# Altium schematic sheet Sheet1.SchDoc(Sheet1) (continued)

## Library data of the parts
C1 C7 C10 C12 C13 C16 C17 C19 C23 = GRM155R71C104KA88D: Multilayer Ceramic Capacitor, 0.1 uF, 16 V, ï¿½ 10%, X7R, 0402 [1005 Metric]; Manufacturer: Murata; Capacitance: 100nF; Dielectric Material: 4241ConductorMaterial; Voltage: 16V; Case Code (Imperial): 0402; Case Code (Metric): 1005; Case/Package: 0402; Category: Ceramic Capacitors; Component Kind: Standard; ComponentLink1Description: https://datasheet.ciiva.com/129/grm155r71c104ka88-01-129739.pdf?src-supplier=Digikey; ComponentLink1URL: https://datasheet.ciiva.com/129/grm155r71c104ka88-01-129739.pdf?src-supplier=Digikey; ComponentLink2Description: https://datasheet.ciiva.com/129/grm155r71c104ka88-01-129740.pdf?src-supplier=Digikey; ComponentLink2URL: https://datasheet.ciiva.com/129/grm155r71c104ka88-01-129740.pdf?src-supplier=Digikey; ComponentLink3Description: https://datasheet.ciiva.com/18102/getdatasheetpartid-85307-18102905.pdf?src-supplier=Verical; ComponentLink3URL: https://datasheet.ciiva.com/18102/getdatasheetpartid-85307-18102905.pdf?src-supplier=Verical; ComponentLink4Description: https://datasheet.ciiva.com/29199/454098-da-01-en-vs-kondensator-0402-29199799.pdf?src-supplier=Conrad; ComponentLink4URL: https://datasheet.ciiva.com/29199/454098-da-01-en-vs-kondensator-0402-29199799.pdf?src-supplier=Conrad; ComponentLink5Description: https://datasheet.ciiva.com/7937/4360-7937615.pdf?src-supplier=Element14; ComponentLink5URL: https://datasheet.ciiva.com/7937/4360-7937615.pdf?src-supplier=Element14; ComponentLink6Description: https://datasheet.ciiva.com/28534/4360-28534127.pdf?src-supplier=Element14; ComponentLink6URL: https://datasheet.ciiva.com/28534/4360-28534127.pdf?src-supplier=Element14; ComponentLink7Description: https://datasheet.ciiva.com/28534/4360-28534133.pdf?src-supplier=Element14; ComponentLink7URL: https://datasheet.ciiva.com/28534/4360-28534133.pdf?src-supplier=Element14; ComponentLink8Description: https://datasheet.ciiva.com/16199/product-16199000.pdf?src-supplier=Future+Electronics; ComponentLink8URL: https://datasheet.ciiva.com/16199/product-16199000.pdf?src-supplier=Future+Electronics; ComponentLink9Description: https://datasheet.ciiva.com/14103/grmseries-highdielectrictype-eng-14103750.pdf?src-supplier=Distrelec; ComponentLink9URL: https://datasheet.ciiva.com/14103/grmseries-highdielectrictype-eng-14103750.pdf?src-supplier=Distrelec; ComponentLink10Description: https://datasheet.ciiva.com/14116/grmseries-highdielectrictype-eng-14116878.pdf?src-supplier=Distrelec; ComponentLink10URL: https://datasheet.ciiva.com/14116/grmseries-highdielectrictype-eng-14116878.pdf?src-supplier=Distrelec; Depth: 0.5mm; Dielectric: X7R; Height: 0.5mm; Lead Free: Lead Free; Length: 1mm; Library Name: Schlib1.SchLib; Manufacturer Part Number: GRM155R71C104KA88D; Material: Ceramic; Max Operating Temperature: 125°C; Min Operating Temperature: -55°C; Mount: Surface Mount; Number of Pins: 2; Package Quantity: 1; Packaging: Tape and Reel; Pricing 1: 10000=0,0044, 40000=0,0043, 100000=0,0042, 250000=0,004, 400000=0,0039 (USD); Radiation Hardening: No; Reach SVHC Compliant: Unknown; RoHS: Yes; RoHS Compliant: Yes; Series: GRM; Stock 1: 33520000; Supplier 1: Future Electronics; Supplier Part Number 1: 5964861; Termination: SMD/SMT; Thickness: 0.022inch; Tolerance: 10%; Voltage Rating: 16V; Voltage Rating (DC): 16V; Width: 0.02inch
C2-C5 = TMK107F474ZA-T: Multilayer Ceramic Capacitors (High dielectric type), 25V, 0.47 uF, +80%, 0603, Y5V/F, RoHS; Manufacturer: Taiyo; Dielectric: Y5V; Value: 470nF; Case/Package: 0603; Component Kind: Standard; Halogen Free: Halogen Free; Height: 800um; Lead Free: Lead Free; Length: 1.6mm; Library Name: Schlib1.SchLib; Manufacturer Part Number: TMK107F474ZA-T; Packaging: Cut Tape; Pins: 2; RoHS: No; Supplier: Newark; Supplier Part Number: 08P5185; Voltage Rating (DC): 25V; Width: 800um
C6 C8 C9 C11 C14 = CC1206KKX7R7BB105: Multilayer Ceramic Capacitor, 1 uF, 16 V, ï¿½ 10%, X7R, 1206 [3216 Metric]; Manufacturer: Yageo; Capacitance: 1uF; Insulation Resistance: 100GR; Material: 4241ConductorMaterial; Voltage Rating: 16V; Case Code (Imperial): 1206; Case Code (Metric): 3216; Case/Package: 1206; Category: Ceramic Capacitors; Component Kind: Standard; ComponentLink1Description: https://datasheet.ciiva.com/178/mlccorderingcode-178166.pdf?src-supplier=Digikey; ComponentLink1URL: https://datasheet.ciiva.com/178/mlccorderingcode-178166.pdf?src-supplier=Digikey; ComponentLink2Description: https://datasheet.ciiva.com/178/mlccorderingcode-178167.pdf?src-supplier=Digikey; ComponentLink2URL: https://datasheet.ciiva.com/178/mlccorderingcode-178167.pdf?src-supplier=Digikey; ComponentLink3Description: https://datasheet.ciiva.com/178/mlccorderingcode-178168.pdf?src-supplier=Digikey; ComponentLink3URL: https://datasheet.ciiva.com/178/mlccorderingcode-178168.pdf?src-supplier=Digikey; ComponentLink4Description: https://datasheet.ciiva.com/7978/1489800-7978229.pdf?src-supplier=Element14; ComponentLink4URL: https://datasheet.ciiva.com/7978/1489800-7978229.pdf?src-supplier=Element14; ComponentLink5Description: https://datasheet.ciiva.com/11464/upy-gphc-x7r-6-3v-to-50v-8-11464763.pdf?src-supplier=Avnet; ComponentLink5URL: https://datasheet.ciiva.com/11464/upy-gphc-x7r-6-3v-to-50v-8-11464763.pdf?src-supplier=Avnet; Depth: 1.6mm; Dielectric: X7R; Dielectric Material: Ceramic; Halogen Free: Halogen Free; Height: 1.15mm; Lead Free: Lead Free; Length: 3.2mm; Library Name: Schlib1.SchLib; Manufacturer Part Number: CC1206KKX7R7BB105; Max Operating Temperature: 125°C; Min Operating Temperature: -55°C; Mount: Surface Mount; Number of Pins: 2; Package Quantity: 1; Packaging: Tape and Reel; Pricing 1: 3000=0,0252 (USD); Radiation Hardening: No; Reach SVHC Compliant: No; RoHS: Yes; RoHS Compliant: Yes; Series: CC; Stock 1: 174000; Supplier 1: Future Electronics; Supplier Part Number 1: 9057753; Termination: SMD/SMT; Thickness: 0.045inch; Tolerance: 10%; Voltage Rating (AC): 16V; Voltage Rating (DC): 16V; Width: 0.063inch
C15 C18 = GRM1555C1H8R0DZ01D: Cap Ceramic 8pF 50V C0G 0.5pF SMD 0402 125°C Paper T/R; Manufacturer: Murata; Case Code (Imperial): 0402; Case Code (Metric): 1005; Case/Package: 0402; Category: Ceramic Capacitors; Component Kind: Standard; ComponentLink1Description: https://datasheet.ciiva.com/3778/8361grm1555c1h8r0dz0123-3778464.pdf?src-supplier=Arrow+Electronics; ComponentLink1URL: https://datasheet.ciiva.com/3778/8361grm1555c1h8r0dz0123-3778464.pdf?src-supplier=Arrow+Electronics; ComponentLink2Description: https://datasheet.ciiva.com/5413/product-5413574.pdf?src-supplier=Mouser; ComponentLink2URL: https://datasheet.ciiva.com/5413/product-5413574.pdf?src-supplier=Mouser; ComponentLink3Description: https://datasheet.ciiva.com/11610/product-11610154.pdf?src-supplier=Avnet; ComponentLink3URL: https://datasheet.ciiva.com/11610/product-11610154.pdf?src-supplier=Avnet; Construction: Flat; Depth: 0.5mm; Dielectric: C0G; Height: 0.5mm; Lead Free: Lead Free; Length: 1mm; Library Name: Schlib1.SchLib; Manufacturer Part Number: GRM1555C1H8R0DZ01D; Max Operating Temperature: 125°C; Min Operating Temperature: -55°C; Mounting Technology: Surface Mount; Package Quantity: 10000; Packaging: Tape and Reel; Pricing: 10=0,05, 100=0,04, 1000=0,04, 10000=0,04 (USD); Radiation Hardening: No; RoHS: Yes; RoHS Compliant: Yes; Series: GRM; Stock: 59869; Supplier: CXDA Electronics; Supplier Part Number: GRM1555C1H8R0DZ01D; Termination: SMD/SMT; Thickness: 0.022inch; Value: 8pF; Voltage Rating: 50V; Voltage Rating (DC): 50V; Width: 0.02inch
C20 C22 = TPSA105K020R3000: Cap Tant Solid 1uF 20V A CASE 10%( 3.2 X 1.6 X 1.6mm) Inward L SMD 3216-18 3 Ohm 125C T/R; Manufacturer: Kyocera AVX; Dielectric Material: Tantalum; Dissipation Factor: 4%; ESR (Equivalent Series Resistance): 3R; Leakage Current: 500nA; Polarity: Polar; Case Code (Imperial): 1206; Case Code (Metric): 3216; Case/Package: 1206; Category: Tantalum Capacitors; Component Kind: Standard; ComponentLink1Description: https://datasheet.ciiva.com/26341/806571-da-01-en-smd-tantal1uf-20v-10-3-000r-2000st-26341825.pdf?src-supplier=Conrad; ComponentLink1URL: https://datasheet.ciiva.com/26341/806571-da-01-en-smd-tantal1uf-20v-10-3-000r-2000st-26341825.pdf?src-supplier=Conrad; ComponentLink2Description: https://datasheet.ciiva.com/263/tps-263911.pdf?src-supplier=Digikey; ComponentLink2URL: https://datasheet.ciiva.com/263/tps-263911.pdf?src-supplier=Digikey; ComponentLink3Description: https://datasheet.ciiva.com/263/tps-263912.pdf?src-supplier=Digikey; ComponentLink3URL: https://datasheet.ciiva.com/263/tps-263912.pdf?src-supplier=Digikey; ComponentLink4Description: https://datasheet.ciiva.com/263/tps-263913.pdf?src-supplier=Digikey; ComponentLink4URL: https://datasheet.ciiva.com/263/tps-263913.pdf?src-supplier=Digikey; ComponentLink5Description: https://datasheet.ciiva.com/7832/1441576-7832617.pdf?src-supplier=Element14; ComponentLink5URL: https://datasheet.ciiva.com/7832/1441576-7832617.pdf?src-supplier=Element14; ComponentLink6Description: https://datasheet.ciiva.com/7832/1441576-7832845.pdf?src-supplier=Element14; ComponentLink6URL: https://datasheet.ciiva.com/7832/1441576-7832845.pdf?src-supplier=Element14; ComponentLink7Description: https://datasheet.ciiva.com/7832/1441576-7832846.pdf?src-supplier=Element14; ComponentLink7URL: https://datasheet.ciiva.com/7832/1441576-7832846.pdf?src-supplier=Element14; ComponentLink8Description: https://datasheet.ciiva.com/7832/tps-7832847.pdf?src-supplier=Element14; ComponentLink8URL: https://datasheet.ciiva.com/7832/tps-7832847.pdf?src-supplier=Element14; ComponentLink9Description: https://datasheet.ciiva.com/28555/1932865-28555241.pdf?src-supplier=Element14; ComponentLink9URL: https://datasheet.ciiva.com/28555/1932865-28555241.pdf?src-supplier=Element14; ComponentLink10Description: https://datasheet.ciiva.com/12910/tps-23028-12910247.pdf?src-supplier=Mouser; ComponentLink10URL: https://datasheet.ciiva.com/12910/tps-23028-12910247.pdf?src-supplier=Mouser; ComponentLink11Description: https://datasheet.ciiva.com/16224/tps-16224686.pdf?src-supplier=Future+Electronics; ComponentLink11URL: https://datasheet.ciiva.com/16224/tps-16224686.pdf?src-supplier=Future+Electronics; ComponentLink12Description: https://datasheet.ciiva.com/14590/70001047-14590583.pdf?src-supplier=Allied+Electronics; ComponentLink12URL: https://datasheet.ciiva.com/14590/70001047-14590583.pdf?src-supplier=Allied+Electronics; ComponentLink13Description: https://datasheet.ciiva.com/14590/70001047-14590587.pdf?src-supplier=Allied+Electronics; ComponentLink13URL: https://datasheet.ciiva.com/14590/70001047-14590587.pdf?src-supplier=Allied+Electronics; ComponentLink14Description: https://datasheet.ciiva.com/3004/tps-3004579.pdf?src-supplier=Avnet; ComponentLink14URL: https://datasheet.ciiva.com/3004/tps-3004579.pdf?src-supplier=Avnet; Construction: Flat; Depth: 1.6mm; Features: General Purpose; Height: 1.6mm; Height - Seated (Max): 0.071inch; Lead Free: Lead Free; Lead Pitch: 1.1mm; Length: 3.2mm; Library Name: Schlib1.SchLib; Manufacturer Part Number: TPSA105K020R3000; Max Operating Temperature: 125°C; Min Operating Temperature: -55°C; Mounting Technology: Surface Mount,PCB; Package Quantity: 1; Packaging: Tape and Reel; Pins: 2; Pricing: 1=0,76, 10=0,459, 50=0,3398, 100=0,3028, 500=0,2398, 1000=0,22014, 2000=0,20387, 4000=0,19039, 6000=0,18361, 10000=0,17607, 14000=0,17164, 20000=0,16737, 50000=0,15812 (USD); Radiation Hardening: No; Reach SVHC Compliant: No; RoHS: Yes; RoHS Compliant: Yes; Series: TPS; Stock: 46298; Supplier: Digikey; Supplier Part Number: 478-2399-1-ND; Termination: SMD/SMT; Tolerance: 10%; Value: 1uF; Voltage: 20V; Voltage Rating: 20V; Voltage Rating (DC): 20V; Weight: 0.000571oz; Width: 1.6mm
C21 = B45197A3107K509: Cap 100UF 16VDC 10% 7.3 X 4.3 X 4.1MM SMD 7343-43 T/r; Manufacturer: KEMET; Dielectric Material: Tantalum; Dissipation Factor: 8%; ESR (Equivalent Series Resistance): 100mR; Leakage Current: 16uA; Voltage: 16V; Capacitance: 100uF; Case Code (Imperial): 2917; Case Code (Metric): 7343; Case/Package: 2917; Category: Tantalum Capacitors; Component Kind: Standard; ComponentLink1Description: https://datasheet.ciiva.com/5934/58264-5934481.pdf?src-supplier=Farnell; ComponentLink1URL: https://datasheet.ciiva.com/5934/58264-5934481.pdf?src-supplier=Farnell; ComponentLink2Description: https://datasheet.ciiva.com/11793/0900766b809b4274-11793801.pdf?src-supplier=Rs; ComponentLink2URL: https://datasheet.ciiva.com/11793/0900766b809b4274-11793801.pdf?src-supplier=Rs; ComponentLink3Description: https://datasheet.ciiva.com/1464/1761160-1464734.pdf?src-supplier=Element14; ComponentLink3URL: https://datasheet.ciiva.com/1464/1761160-1464734.pdf?src-supplier=Element14; ComponentLink4Description: https://datasheet.ciiva.com/1464/1761160-1464735.pdf?src-supplier=Element14; ComponentLink4URL: https://datasheet.ciiva.com/1464/1761160-1464735.pdf?src-supplier=Element14; Depth: 4.3mm; Height: 4.4mm; Lead Free: Lead Free; Length: 7.3mm; Library Name: Schlib1.SchLib; Manufacturer Part Number: B45197A3107K509; Max Operating Temperature: 125°C; Min Operating Temperature: -55°C; Mount: Surface Mount; Number of Pins: 2; Packaging: Tape and Reel; Pricing 1: 1=2,31, 10=1,54, 50=1,07, 100=0,889, 200=0,771, 400=0,66, 2000=0,578 (GBP); Reach SVHC Compliant: No; RoHS: Yes; RoHS Compliant: Yes; Series: B45197A; Supplier 1: Farnell; Supplier Part Number 1: 9751084; Termination: SMD/SMT; Tolerance: 10%; Voltage Rating: 16V; Voltage Rating (DC): 16V; Weight: 0.023634oz; Width: 4.3mm
C24 = TAJA105K016RNJ: Cap Tant Solid 1uF 16V A CASE 10%( 3.2 X 1.6 X 1.6mm) Inward L SMD 3216-18 11 Ohm 125C T/R; Manufacturer: Kyocera AVX; Dielectric Material: Tantalum; Dissipation Factor: 4%; ESR (Equivalent Series Resistance): 11R; Leakage Current: 500nA; Life (Hours): 2000Hour; Ripple Current: 83mA; Tolerance: 20%; Capacitance: 1uF; Case Code (Imperial): 1206; Case Code (Metric): 3216; Case/Package: 1206; Category: Tantalum Capacitors; Component Kind: Standard; ComponentLink1Description: https://datasheet.ciiva.com/263/taj-263409.pdf?src-supplier=Digikey; ComponentLink1URL: https://datasheet.ciiva.com/263/taj-263409.pdf?src-supplier=Digikey; ComponentLink2Description: https://datasheet.ciiva.com/263/taj-263410.pdf?src-supplier=Digikey; ComponentLink2URL: https://datasheet.ciiva.com/263/taj-263410.pdf?src-supplier=Digikey; ComponentLink3Description: https://datasheet.ciiva.com/263/taj-263411.pdf?src-supplier=Digikey; ComponentLink3URL: https://datasheet.ciiva.com/263/taj-263411.pdf?src-supplier=Digikey; ComponentLink4Description: https://datasheet.ciiva.com/18710/taj-eng-18710647.pdf?src-supplier=Distrelec; ComponentLink4URL: https://datasheet.ciiva.com/18710/taj-eng-18710647.pdf?src-supplier=Distrelec; ComponentLink5Description: https://datasheet.ciiva.com/7832/1703059-7832429.pdf?src-supplier=Element14; ComponentLink5URL: https://datasheet.ciiva.com/7832/1703059-7832429.pdf?src-supplier=Element14; ComponentLink6Description: https://datasheet.ciiva.com/7832/1703059-7832430.pdf?src-supplier=Element14; ComponentLink6URL: https://datasheet.ciiva.com/7832/1703059-7832430.pdf?src-supplier=Element14; ComponentLink7Description: https://datasheet.ciiva.com/7832/1680476-7832431.pdf?src-supplier=Element14; ComponentLink7URL: https://datasheet.ciiva.com/7832/1680476-7832431.pdf?src-supplier=Element14; ComponentLink8Description: https://datasheet.ciiva.com/7832/taj-7832810.pdf?src-supplier=Element14; ComponentLink8URL: https://datasheet.ciiva.com/7832/taj-7832810.pdf?src-supplier=Element14; ComponentLink9Description: https://datasheet.ciiva.com/7832/taj-7832811.pdf?src-supplier=Element14; ComponentLink9URL: https://datasheet.ciiva.com/7832/taj-7832811.pdf?src-supplier=Element14; Depth: 1.6mm; Features: General Purpose; Height: 1.6mm; Lead Free: Lead Free; Lead Pitch: 1.1mm; Length: 3.2mm; Library Name: Schlib1.SchLib; Manufacturer Part Number: TAJA105K016RNJ; Max Operating Temperature: 125°C; Min Operating Temperature: -55°C; Mount: Surface Mount,PCB; Number of Pins: 2; Packaging: Tape and Reel; Pricing 1: 1=0,38, 10=0,225, 50=0,1626, 100=0,1431, 500=0,11, 1000=0,09966 (USD); Reach SVHC Compliant: No; RoHS: Yes; RoHS Compliant: Yes; Series: TAJ; Stock 1: 80915; Supplier 1: Digikey; Supplier Part Number 1: 478-1649-1-ND; Termination: SMD/SMT; Voltage: 16V; Voltage Rating: 16V; Voltage Rating (AC): 16V; Voltage Rating (DC): 16V; Weight: 0.000571oz; Width: 1.6mm
D1 D2 = LNJ314G8TRA: Led SMT Grn Cut Tapes; Manufacturer: Panasonic SSG; Color: Green; Forward Current: 10mA; Forward Voltage: 2.03V; Lens Size: 0.8mm; Lens Style: Flat,Rectangular; Luminous Intensity: 5mcd; Number of LEDs: 1; Power Rating: 40mW; Wavelength: 565nm; Case Code (Imperial): 0603; Case Code (Metric): 1608; Case/Package: 0603; Category: LEDs; Component Kind: Standard; ComponentLink1Description: https://datasheet.ciiva.com/9326/lnj314g8tra-bek-discon-9326750.pdf?src-supplier=Avnet; ComponentLink1URL: https://datasheet.ciiva.com/9326/lnj314g8tra-bek-discon-9326750.pdf?src-supplier=Avnet; Height: 0.5mm; Illumination Color: Green; Lead Free: Lead Free; Length: 1.6mm; Library Name: Schlib1.SchLib; Manufacturer Part Number: LNJ314G8TRA; Max Operating Temperature: 85°C; Min Operating Temperature: -30°C; Mount: Surface Mount; Number of Pins: 2; Packaging: Tape and Reel; Pricing 1: 1=1,03385 (USD); Radiation Hardening: No; RoHS: Yes; RoHS Compliant: Yes; Series: -; Stock 1: 450; Supplier 1: Ampacity Systems; Supplier Part Number 1: LNJ314G8TRA; Test Current: 10mA; Width: 0.8mm
D3 = SD0805S020S1R0: Small Signal Schottky Diode, Single, 20 V, 1 A, 450 Mv, 10 A, 125 C Rohs Compliant: Yes; Manufacturer: Kyocera AVX; Average Rectified Current: 1A; Forward Voltage: 450mV; Max Forward Surge Current (Ifsm): 10A; Max Repetitive Reverse Voltage (Vrrm): 20V; Max Reverse Current: 200uA; Case Code (Imperial): 0805; Case Code (Metric): 2012; Case/Package: 0805; Category: Rectifier Diodes; Component Kind: Standard; ComponentLink1Description: https://datasheet.ciiva.com/29883/schottky-29883740.pdf?src-supplier=Avnet; ComponentLink1URL: https://datasheet.ciiva.com/29883/schottky-29883740.pdf?src-supplier=Avnet; ComponentLink2Description: https://datasheet.ciiva.com/29883/schottky-29883741.pdf?src-supplier=Avnet; ComponentLink2URL: https://datasheet.ciiva.com/29883/schottky-29883741.pdf?src-supplier=Avnet; ComponentLink3Description: https://datasheet.ciiva.com/13084/schottky-221155-13084174.pdf?src-supplier=Mouser; ComponentLink3URL: https://datasheet.ciiva.com/13084/schottky-221155-13084174.pdf?src-supplier=Mouser; Forward Current: 1A; Library Name: Schlib1.SchLib; Manufacturer Part Number: SD0805S020S1R0; Max Operating Temperature: 125°C; Max Reverse Leakage Current: 200uA; Max Reverse Voltage (DC): 20V; Max Surge Current: 10A; Min Operating Temperature: -55°C; Mounting Technology: Surface Mount; Packaging: Cut Tape; Peak Non-Repetitive Surge Current: 10A; Pins: 2; Pricing: 1=0,51, 10=0,311, 100=0,1972, 500=0,14792, 1000=0,132, 3000=0,11174, 6000=0,10152, 9000=0,09631, 15000=0,09046, 21000=0,08699, 30000=0,08362, 75000=0,07622 (USD); Radiation Hardening: No; Reach SVHC Compliant: No; RoHS: Yes; RoHS Compliant: Yes; Series: -; Stock: 72775; Supplier: Digikey; Supplier Part Number: 478-7800-1-ND
H1 H2 = 1725656: Block, Terminal; 6 A; 63 V; 2.54 mm; 26 to 20 AWG; 2; Screw; Soldering; 1.5 kV; Manufacturer: Phoenix Contact; Approvals: UL,CSA,cULus,EAC; Connector Type: Screw,PCB,Terminal Block; Current: 6A; Max Supply Voltage: 63V; Number of Circuits: 2; Number of Levels: 1; Pitch: 2.54mm; Stripping Length: 4.5mm; Voltage: 125V; Wire Gauge (Max): 26AWG; Wire Gauge (Min): 20AWG; Category: Terminal Blocks; Color: Green; Component Kind: Standard; ComponentLink1Description: https://datasheet.ciiva.com/823/1725656-823793.pdf?src-supplier=Digikey; ComponentLink1URL: https://datasheet.ciiva.com/823/1725656-823793.pdf?src-supplier=Digikey; ComponentLink2Description: https://datasheet.ciiva.com/1120/319957-1120510.pdf?src-supplier=Farnell; ComponentLink2URL: https://datasheet.ciiva.com/1120/319957-1120510.pdf?src-supplier=Farnell; ComponentLink3Description: https://datasheet.ciiva.com/15169/getdatasheetpartid-199323-15169849.pdf?src-supplier=Verical; ComponentLink3URL: https://datasheet.ciiva.com/15169/getdatasheetpartid-199323-15169849.pdf?src-supplier=Verical; ComponentLink4Description: https://datasheet.ciiva.com/16071/1725656-16071846.pdf?src-supplier=Onlinecomponents.com; ComponentLink4URL: https://datasheet.ciiva.com/16071/1725656-16071846.pdf?src-supplier=Onlinecomponents.com; ComponentLink5Description: https://datasheet.ciiva.com/26340/788052-da-01-en-100ntklemme-1725656-250-st-26340907.pdf?src-supplier=Conrad; ComponentLink5URL: https://datasheet.ciiva.com/26340/788052-da-01-en-100ntklemme-1725656-250-st-26340907.pdf?src-supplier=Conrad; Contact Material: Tin; Current Rating: 6A; Depth: 6.2mm; Flammability Rating: UL94 V-0; Height: 6.2mm; Housing Color: 49Colour; Housing Material: Polyamide; Insulation Material: 4261ConductorMaterial; Lead Free: Lead Free; Length: 5.54mm; Library Name: Schlib1.SchLib; Manufacturer Part Number: 1725656; Max Current Rating: 6A; Mount: Through Hole; Number of Contacts: 2; Number of Pins: 2; Number of Poles: 2; Number of Positions: 2; Number of Positions Per Level: 2; Number of Rows: 1; Orientation: Straight; Package Quantity: 50; Packaging: Bulk; Pricing 1: 1=1,66, 10=1,14, 50=1,14, 100=1,14, 1000=1,13, 10000=1,13 (USD); Radiation Hardening: No; Reach SVHC Compliant: No; RoHS: Yes; RoHS Compliant: Yes; Series: MPT; Stock 1: 18801; Supplier 1: Mouser; Supplier Part Number 1: 651-1725656; Termination: Solder; Voltage Rating: 125V; Weight: 0.022575oz; Width: 12mm; Wire/Cable Gauge: 26AWG
H3 = 1725669: Terminal Block Connector; 6 A; 63 V; 2.54 mm; 26 to 20 AWG; 3; Screw; Soldering; 1.5 kV; Manufacturer: Phoenix Contact; Approvals: UL,CSA,cULus,EAC; Connector Type: Screw,PCB,Terminal Block; Current: 6A; Max Supply Voltage: 63V; Number of Circuits: 3; Number of Levels: 1; Pitch: 2.54mm; Stripping Length: 4.5mm; Voltage: 125V; Wire Gauge (Max): 26AWG; Wire Gauge (Min): 20AWG; Category: Terminal Blocks; Color: Green; Component Kind: Standard; ComponentLink1Description: https://datasheet.ciiva.com/823/1725669-823803.pdf?src-supplier=Digikey; ComponentLink1URL: https://datasheet.ciiva.com/823/1725669-823803.pdf?src-supplier=Digikey; ComponentLink2Description: https://datasheet.ciiva.com/1118/1761576-1118544.pdf?src-supplier=Element14; ComponentLink2URL: https://datasheet.ciiva.com/1118/1761576-1118544.pdf?src-supplier=Element14; ComponentLink3Description: https://datasheet.ciiva.com/7053/312657-7053092.pdf?src-supplier=Newark; ComponentLink3URL: https://datasheet.ciiva.com/7053/312657-7053092.pdf?src-supplier=Newark; ComponentLink4Description: https://datasheet.ciiva.com/3969/1725669-3969975.pdf?src-supplier=Arrow+Electronics; ComponentLink4URL: https://datasheet.ciiva.com/3969/1725669-3969975.pdf?src-supplier=Arrow+Electronics; ComponentLink5Description: https://datasheet.ciiva.com/15169/getdatasheetpartid-178016-15169707.pdf?src-supplier=Verical; ComponentLink5URL: https://datasheet.ciiva.com/15169/getdatasheetpartid-178016-15169707.pdf?src-supplier=Verical; ComponentLink6Description: https://datasheet.ciiva.com/16071/1725669-16071848.pdf?src-supplier=Onlinecomponents.com; ComponentLink6URL: https://datasheet.ciiva.com/16071/1725669-16071848.pdf?src-supplier=Onlinecomponents.com; ComponentLink7Description: https://datasheet.ciiva.com/14607/70054336-14607349.pdf?src-supplier=Allied+Electronics; ComponentLink7URL: https://datasheet.ciiva.com/14607/70054336-14607349.pdf?src-supplier=Allied+Electronics; ComponentLink8Description: https://datasheet.ciiva.com/29223/717267-da-01-en-printklemme-1725669-250-st-29223852.pdf?src-supplier=Conrad; ComponentLink8URL: https://datasheet.ciiva.com/29223/717267-da-01-en-printklemme-1725669-250-st-29223852.pdf?src-supplier=Conrad; Contact Material: Tin; Current Rating: 6A; Depth: 6.2mm; Flammability Rating: UL94 V-0; Height: 8.08mm; Housing Color: Green; Housing Material: Polyamide; Insulation Material: Nylon; Lead Free: Lead Free; Length: 6.2mm; Library Name: Schlib1.SchLib; Manufacturer Part Number: 1725669; Max Current Rating: 6A; Mount: Through Hole; Number of Contacts: 3; Number of Pins: 3; Number of Poles: 3; Number of Positions: 3; Number of Positions Per Level: 3; Number of Rows: 1; Orientation: Straight; Package Quantity: 250; Packaging: Bulk; Pricing 1: 10=2,04, 100=1,77, 250=1,72, 500=1,68, 1000=1,64, 2500=1,61, 5000=1,59, 7500=1,57 (USD); Radiation Hardening: No; Reach SVHC Compliant: No; RoHS: Yes; RoHS Compliant: Yes; Series: MPT; Stock 1: 743; Supplier 1: Onlinecomponents.com; Supplier Part Number 1: 1725669; Termination: Solder; Voltage Rating: 125V; Weight: 0.021658oz; Width: 13mm; Wire/Cable Gauge: 26AWG
H4 = MPT0,5/4-2,54: Wire-To-Board Terminal Block Rating to EN: 6 A @ 63 VAC/VDC Rating to UL: 6 A @ 125 VAC/VDC Temperature range: -20...; Manufacturer: Phoenix Contact; Max Current Rating: 6A; Max Supply Voltage: 63V; Wire Gauge (Max): 26AWG; Wire Gauge (Min): 20AWG; Category: Terminal Blocks; Component Kind: Standard; ComponentLink1Description: https://www.distrelec.biz/Web/Downloads/20/76/uu142076.pdf?mime=application%2Fpdf&src-supplier=Distrelec; ComponentLink1URL: https://www.distrelec.biz/Web/Downloads/20/76/uu142076.pdf?mime=application%2Fpdf&src-supplier=Distrelec; Depth: 6.2mm; Housing Material: 4261ConductorMaterial; Length: 10.62mm; Library Name: Schlib1.SchLib; Manufacturer Part Number: MPT0,5/4-2,54; Number of Pins: 4; Number of Rows: 1NUMBER; Orientation: 5491OrientationType; RoHS: Yes; RoHS Compliant: Yes; Stock 1: 49; Supplier 1: Sourcengine; Supplier Part Number 1: MPT0,5/4-2,54; Termination: Screw
Q1 = BC817-25,235: BC817 Series 45V 500mA SMT NPN General Purpose Transistor - SOT-23; Manufacturer: Nexperia; Collector Base Voltage (VCBO): 50V; Collector Emitter Saturation Voltage: 700mV; Emitter Base Voltage (VEBO): 5V; hFE Min: 160; Number of Elements: 1; Power Dissipation: 250mW; Category: BJTs; Collector Emitter Breakdown Voltage: 45V; Collector Emitter Voltage (VCEO): 45V; Component Kind: Standard; ComponentLink1Description: https://datasheet.octopart.com/BC817-25%2C235-Nexperia-datasheet-108335331.pdf?src-supplier=Farnell; ComponentLink1URL: https://datasheet.octopart.com/BC817-25%2C235-Nexperia-datasheet-108335331.pdf?src-supplier=Farnell; ComponentLink2Description: https://assets.nexperia.com/documents/data-sheet/BC817_SER.pdf?src-supplier=Digikey; ComponentLink2URL: https://assets.nexperia.com/documents/data-sheet/BC817_SER.pdf?src-supplier=Digikey; Height: 1.1mm; Library Name: Schlib1.SchLib; Manufacturer Part Number: BC817-25,235; Max Collector Current: 500mA; Max Operating Temperature: 150°C; Number of Pins: 3; Packaging: Tape and Reel; Polarity: NPN; Pricing 1: 10000=0,0123, 30000=0,0119, 50000=0,0117, 100000=0,0114, 200000=0,011 (USD); RoHS: Yes; RoHS Compliant: Yes; Stock 1: 6440000; Supplier 1: Future Electronics; Supplier Part Number 1: 3451903
Q2 Q3 = MMBT6427-7-F: MMBT6427 Series 40 V 500 mA NPN Surface Mount Darlington Transistor - SOT-23-3; Manufacturer: Diodes; Collector Base Voltage (VCBO): 40V; Collector Emitter Saturation Voltage: 1.2V; Collector-emitter Voltage-Max: 1.5V; Current Rating: 500mA; Emitter Base Voltage (VEBO): 12V; hFE Min: 10000; Max Power Dissipation: 300mW; Number of Elements: 1; Case/Package: SOT-23; Category: BJTs; Collector Emitter Breakdown Voltage: 40V; Collector Emitter Voltage (VCEO): 40V; Component Kind: Standard; ComponentLink1Description: https://datasheet.ciiva.com/11211/ds30048-11211443.pdf?src-supplier=Avnet; ComponentLink1URL: https://datasheet.ciiva.com/11211/ds30048-11211443.pdf?src-supplier=Avnet; ComponentLink2Description: https://datasheet.ciiva.com/4459/ds30048-82966-4459317.pdf?src-supplier=Mouser; ComponentLink2URL: https://datasheet.ciiva.com/4459/ds30048-82966-4459317.pdf?src-supplier=Mouser; ComponentLink3Description: https://datasheet.ciiva.com/15139/getdatasheetpartid-67553-15139920.pdf?src-supplier=Verical; ComponentLink3URL: https://datasheet.ciiva.com/15139/getdatasheetpartid-67553-15139920.pdf?src-supplier=Verical; ComponentLink4Description: https://datasheet.ciiva.com/973/ds30048-973062.pdf?src-supplier=Digikey; ComponentLink4URL: https://datasheet.ciiva.com/973/ds30048-973062.pdf?src-supplier=Digikey; ComponentLink5Description: https://datasheet.ciiva.com/973/ds30048-973063.pdf?src-supplier=Digikey; ComponentLink5URL: https://datasheet.ciiva.com/973/ds30048-973063.pdf?src-supplier=Digikey; Height: 1mm; Lead Free: Lead Free; Length: 3.05mm; Library Name: Schlib1.SchLib; Manufacturer Part Number: MMBT6427-7-F; Max Breakdown Voltage: 40V; Max Collector Current: 500mA; Max Operating Temperature: 150°C; Min Operating Temperature: -55°C; Mount: Surface Mount; Number of Pins: 3; Package Quantity: 3000; Packaging: Tape and Reel; Polarity: NPN; Pricing 1: 1=0,21, 10=0,128, 100=0,0796, 500=0,05812, 1000=0,05115 (USD); Radiation Hardening: No; RoHS: Yes; RoHS Compliant: Yes; Series: Automotive, AEC-Q101; Stock 1: 25429; Supplier 1: Digikey; Supplier Part Number 1: MMBT6427-FDICT-ND; Voltage Rating (DC): 40V; Weight: 0.000282oz; Width: 1.4mm
R1 R3 R11 R15 R18 = CRCW04021K00JNED: Res Thick Film 0402 1K Ohm 5% 1/16W ±200ppm/°C Molded SMD SMD Paper T/R; Manufacturer: Vishay Dale; Max Power Dissipation: 63mW; Resistance: 1kR; Voltage Rating: 50V; Case Code (Imperial): 0402; Case Code (Metric): 1005; Case/Package: 0402; Category: Chip SMD Resistors; Component Kind: Standard; ComponentLink1Description: https://datasheet.ciiva.com/2462/dcrcwe3-2462076.pdf?src-supplier=Digikey; ComponentLink1URL: https://datasheet.ciiva.com/2462/dcrcwe3-2462076.pdf?src-supplier=Digikey; ComponentLink2Description: https://datasheet.ciiva.com/2462/dcrcwe3-2462077.pdf?src-supplier=Digikey; ComponentLink2URL: https://datasheet.ciiva.com/2462/dcrcwe3-2462077.pdf?src-supplier=Digikey; ComponentLink3Description: https://datasheet.ciiva.com/2462/dcrcwe3-2462078.pdf?src-supplier=Digikey; ComponentLink3URL: https://datasheet.ciiva.com/2462/dcrcwe3-2462078.pdf?src-supplier=Digikey; ComponentLink4Description: https://datasheet.ciiva.com/7743/dcrcwe3-7743478.pdf?src-supplier=Element14; ComponentLink4URL: https://datasheet.ciiva.com/7743/dcrcwe3-7743478.pdf?src-supplier=Element14; ComponentLink5Description: https://datasheet.ciiva.com/5545/dcrcwe3-109170-5545913.pdf?src-supplier=Mouser; ComponentLink5URL: https://datasheet.ciiva.com/5545/dcrcwe3-109170-5545913.pdf?src-supplier=Mouser; ComponentLink6Description: https://datasheet.ciiva.com/28621/1847332-28621342.pdf?src-supplier=Element14; ComponentLink6URL: https://datasheet.ciiva.com/28621/1847332-28621342.pdf?src-supplier=Element14; ComponentLink7Description: https://datasheet.ciiva.com/16199/dcrcwe3-16199256.pdf?src-supplier=Future+Electronics; ComponentLink7URL: https://datasheet.ciiva.com/16199/dcrcwe3-16199256.pdf?src-supplier=Future+Electronics; ComponentLink8Description: https://datasheet.ciiva.com/16778/70201119-16778053.pdf?src-supplier=Allied+Electronics; ComponentLink8URL: https://datasheet.ciiva.com/16778/70201119-16778053.pdf?src-supplier=Allied+Electronics; Construction: Rectangular; Contact Plating: Tin; Depth: 0.5mm; Features: Automotive AEC-Q200; Height: 0.016inch; Lead Free: Lead Free; Length: 1mm; Library Name: Schlib1.SchLib; Manufacturer Part Number: CRCW04021K00JNED; Max Operating Temperature: 155°C; Military Standard: Not; Min Operating Temperature: -55°C; Number of Pins: 2; Number of Terminations: 2; Package Quantity: 1; Packaging: Tape and Reel; Power Rating: 63mW; Pricing 1: 1=0,1, 10=0,016, 50=0,016, 100=0,009, 1000=0,008, 2500=0,006, 10000=0,004, 20000=0,003 (USD); Radiation Hardening: No; Ratings: AEC-Q200; Reach SVHC Compliant: Unknown; Resistance Tolerance: 5%; Resistor Type: Thick Film; RoHS: Yes; RoHS Compliant: Yes; Series: CRCW; Stock 1: 255010; Supplier 1: Mouser; Supplier Part Number 1: 71-CRCW0402J-1.0K-E3; Technology: THICK FILM; Temperature Coefficient: 200ppm/°C; Termination: SMD/SMT; Tolerance: 5%; Voltage Rating (DC): 50V; Weight: 2.3E-05oz; Width: 0.02inch; Working Voltage: 50V
R2 R4 R17 = CRCW0402560RJNED: SMD Chip Resistor, 560 Ohm, ± 5%, 63 mW, 0402 [1005 Metric], Thick Film, General Purpose; Manufacturer: Vishay Dale; Max Power Dissipation: 63mW; Temperature Coefficient: 200ppm/°C; Voltage Rating: 50V; Case Code (Imperial): 0402; Case Code (Metric): 1005; Case/Package: 0402; Category: Chip SMD Resistors; Component Kind: Standard; ComponentLink1Description: https://datasheet.ciiva.com/2524/dcrcwe3-2524414.pdf?src-supplier=Digikey; ComponentLink1URL: https://datasheet.ciiva.com/2524/dcrcwe3-2524414.pdf?src-supplier=Digikey; ComponentLink2Description: https://datasheet.ciiva.com/2524/dcrcwe3-2524415.pdf?src-supplier=Digikey; ComponentLink2URL: https://datasheet.ciiva.com/2524/dcrcwe3-2524415.pdf?src-supplier=Digikey; ComponentLink3Description: https://datasheet.ciiva.com/2524/dcrcwe3-2524416.pdf?src-supplier=Digikey; ComponentLink3URL: https://datasheet.ciiva.com/2524/dcrcwe3-2524416.pdf?src-supplier=Digikey; ComponentLink4Description: https://datasheet.ciiva.com/3256/dcrcwe3-3256649.pdf?src-supplier=Avnet; ComponentLink4URL: https://datasheet.ciiva.com/3256/dcrcwe3-3256649.pdf?src-supplier=Avnet; ComponentLink5Description: https://datasheet.ciiva.com/3256/dcrcwe3-3256650.pdf?src-supplier=Avnet; ComponentLink5URL: https://datasheet.ciiva.com/3256/dcrcwe3-3256650.pdf?src-supplier=Avnet; ComponentLink6Description: https://datasheet.ciiva.com/16436/dcrcwe3-16436119.pdf?src-supplier=Future+Electronics; ComponentLink6URL: https://datasheet.ciiva.com/16436/dcrcwe3-16436119.pdf?src-supplier=Future+Electronics; ComponentLink7Description: https://datasheet.ciiva.com/5548/dcrcwe3-109170-5548972.pdf?src-supplier=Mouser; ComponentLink7URL: https://datasheet.ciiva.com/5548/dcrcwe3-109170-5548972.pdf?src-supplier=Mouser; Contact Plating: Tin; Features: Automotive AEC-Q200; Height: 0.35mm; Lead Free: Lead Free; Length: 1mm; Library Name: Schlib1.SchLib; Manufacturer Part Number: CRCW0402560RJNED; Max Operating Temperature: 155°C; Min Operating Temperature: -55°C; Number of Pins: 2; Number of Terminations: 2; Package Quantity: 10000; Packaging: Cut Tape; Power Rating: 63mW; Pricing 1: 1=0,1, 10=0,015, 50=0,015, 100=0,009, 1000=0,007, 2500=0,006, 10000=0,004 (USD); Radiation Hardening: No; Ratings: AEC-Q200; Reach SVHC Compliant: Unknown; Resistance: 560R; Resistance Tolerance: 5%; Resistor Type: Thick Film; RoHS: Yes; RoHS Compliant: Yes; Series: CRCW; Size Code: 0402; Stock 1: 36463; Supplier 1: Mouser; Supplier Part Number 1: 71-CRCW0402J-560-E3; Tolerance: 5%; Voltage Rating (DC): 50V; Weight: 2.3E-05oz; Width: 0.5mm
R5 R6 R8 R9 R12-R14 R16 R20 R21 = ERJ-PA3F1003V: SMD Chip Resistor, 100 kOhm, ± 1%, 250 mW, 0603 [1608 Metric], Thick Film, Anti-Surge; Manufacturer: Panasonic; Max Power Dissipation: 250mW; Resistance: 100kR; Temperature Coefficient: 100ppm/°C; Voltage Rating: 150V; Case Code (Imperial): 0603; Case Code (Metric): 1608; Case/Package: 0603; Category: Chip SMD Resistors; Component Kind: Standard; ComponentLink1Description: https://datasheet.ciiva.com/22781/1801181-22781557.pdf?src-supplier=Farnell; ComponentLink1URL: https://datasheet.ciiva.com/22781/1801181-22781557.pdf?src-supplier=Farnell; ComponentLink2Description: https://datasheet.ciiva.com/49048/1801181-49048299.pdf?src-supplier=Element14; ComponentLink2URL: https://datasheet.ciiva.com/49048/1801181-49048299.pdf?src-supplier=Element14; Depth: 0.8mm; Features: Automotive AEC-Q200; Height: 0.018inch; Length: 0.063inch; Library Name: Schlib1.SchLib; Manufacturer Part Number: ERJ-PA3F1003V; Max Operating Temperature: 155°C; Min Operating Temperature: -55°C; Mount: Surface Mount; Number of Terminations: 2; Packaging: Cut Tape; Power Rating: 250mW; Pricing 1: 1=0,14, 10=0,071, 50=0,0468, 100=0,0397, 500=0,0282, 1000=0,02477 (USD); Ratings: AEC-Q200; Reach SVHC Compliant: No; Resistance Tolerance: 1%; Resistor Type: Thick Film; RoHS: Yes; Series: ERJ; Stock 1: 59135; Supplier 1: Digikey; Supplier Part Number 1: P100KBYCT-ND; Technology: THICK FILM; Termination: Solder; Tolerance: 1%; Voltage Rating (DC): 150V; Width: 0.031inch
R7 R19 = RCA0402100KFKED: RCA FIXED RESISTOR 0402 (1005Metric) Chip Resistor ±1% ±100ppm/C 100kOhm 0.063W 1/16W; Manufacturer: Vishay Dale; Max Power Dissipation: 63mW; Resistance: 100kR; Temperature Coefficient: 100ppm/°C; Voltage Rating: 50V; Case Code (Imperial): 0402; Case Code (Metric): 1005; Case/Package: 0402; Category: Chip SMD Resistors; Component Kind: Standard; ComponentLink1Description: https://datasheet.ciiva.com/8980/rcae3-8980646.pdf?src-supplier=Avnet; ComponentLink1URL: https://datasheet.ciiva.com/8980/rcae3-8980646.pdf?src-supplier=Avnet; Contact Plating: Tin; Features: Automotive AEC-Q200,Anti-Sulfur; Height: 0.016inch; Lead Free: Lead Free; Length: 0.039inch; Library Name: Schlib1.SchLib; Manufacturer Part Number: RCA0402100KFKED; Max Operating Temperature: 155°C; Min Operating Temperature: -55°C; Number of Terminations: 2; Packaging: Tape and Reel; Power Rating: 63mW; Pricing 1: 1=0,1, 10=0,027, 50=0,027, 100=0,016, 1000=0,013, 2500=0,011, 10000=0,01 (USD); Radiation Hardening: No; Reach SVHC Compliant: No; Resistance Tolerance: 1%; Resistor Type: Thick Film; RoHS: Yes; RoHS Compliant: Yes; Series: RCA; Stock 1: 20781; Supplier 1: Mouser; Supplier Part Number 1: 71-RCA0402100KFKED; Tolerance: 1%; Voltage Rating (DC): 50V; Width: 0.02inch
R10 = CRCW04021M00FKEDC: Res Thick Film 0402 1M Ohm 1% 0.063W(1/16W) ±100ppm/C Pad SMD T/R; Manufacturer: Vishay Semiconductors; Max Power Dissipation: 63mW; Resistance: 1MR; Temperature Coefficient: 100ppm/°C; Voltage Rating: 50V; Case/Package: 0402; Category: Chip SMD Resistors; Component Kind: Standard; ComponentLink1Description: http://www.vishay.com/docs/28773/crcwce3.pdf?src-supplier=Digikey; ComponentLink1URL: http://www.vishay.com/docs/28773/crcwce3.pdf?src-supplier=Digikey; ComponentLink2Description: https://datasheet.octopart.com/CRCW04021M00FKEDC-Vishay-datasheet-100063072.pdf?src-supplier=Future+Electronics; ComponentLink2URL: https://datasheet.octopart.com/CRCW04021M00FKEDC-Vishay-datasheet-100063072.pdf?src-supplier=Future+Electronics; Height: 350um; Lead Free: 5697LeadFree; Library Name: Schlib1.SchLib; Manufacturer Part Number: CRCW04021M00FKEDC; Max Operating Temperature: 155°C; Min Operating Temperature: -55°C; Number of Terminations: 2; Packaging: Tape and Reel; Pricing 1: 1=0,1, 10=0,016, 50=0,016, 100=0,009, 1000=0,007, 2500=0,005, 10000=0,003 (USD); RoHS: Yes; RoHS Compliant: Yes; Series: CRCW-C; Stock 1: 316158; Supplier 1: Mouser; Supplier Part Number 1: 71-CRCW04021M00FKEDC; Tolerance: 1%
U1 = MMA3201KEGR2: Accelerometer Dual ±40g 4.75V to 5.25V 47.5mV/g to 52.5mV/g 20-Pin SOIC T/R; Manufacturer: NXP Freescale; Case/Package: SOIC; Category: Accelerometers; Component Kind: Standard; ComponentLink1Description: https://datasheet.ciiva.com/3271/mma3201keg-3271720.pdf?src-supplier=Avnet; ComponentLink1URL: https://datasheet.ciiva.com/3271/mma3201keg-3271720.pdf?src-supplier=Avnet; Library Name: Schlib1.SchLib; Manufacturer Part Number: MMA3201KEGR2; Max Operating Temperature: 125°C; Min Operating Temperature: -40°C; Mount: Surface Mount; Number of Pins: 20; Operating Supply Voltage: 5.25V; Package Quantity: 1000; Packaging: Tape and Reel; Pricing 1: 1000=10,72 (USD); RoHS: Yes; RoHS Compliant: Yes; Series: MMA3201; Supplier 1: Newark; Supplier Part Number 1: 85AK8921; Weight: 0.008826oz
U2 = MAX3232IPWR: IC DRVR/RCVR MLTCH RS232 16TSSOP; Manufacturer: Texas Instruments; Data Rate: 250Kbps; Logic Function: Transceiver,Receiver; Max Supply Voltage: 5.5V; Min Supply Voltage: 3V; Number of Drivers: 2; Number of Transceivers: 1; Operating Supply Current: 1mA; Operating Supply Voltage: 5V; Simplex/Duplex: Full Duplex; Case/Package: TSSOP; Category: Interface ICs; Component Kind: Standard; ComponentLink1Description: https://datasheet.ciiva.com/1702/max3232-1702050.pdf?src-supplier=Digikey; ComponentLink1URL: https://datasheet.ciiva.com/1702/max3232-1702050.pdf?src-supplier=Digikey; ComponentLink2Description: https://datasheet.ciiva.com/1702/max3232-1702051.pdf?src-supplier=Digikey; ComponentLink2URL: https://datasheet.ciiva.com/1702/max3232-1702051.pdf?src-supplier=Digikey; ComponentLink3Description: https://datasheet.ciiva.com/1702/max3232-1702052.pdf?src-supplier=Digikey; ComponentLink3URL: https://datasheet.ciiva.com/1702/max3232-1702052.pdf?src-supplier=Digikey; ComponentLink4Description: https://datasheet.ciiva.com/8064/1882754-8064747.pdf?src-supplier=Element14; ComponentLink4URL: https://datasheet.ciiva.com/8064/1882754-8064747.pdf?src-supplier=Element14; ComponentLink5Description: https://datasheet.ciiva.com/46384/1882754-46384398.pdf?src-supplier=Element14; ComponentLink5URL: https://datasheet.ciiva.com/46384/1882754-46384398.pdf?src-supplier=Element14; ComponentLink6Description: https://datasheet.ciiva.com/48709/001047902-da-01-en-ic-drv-rx-mulch-max3232ipwr-tssop-16-tid-48709190.pdf?src-supplier=Conrad; ComponentLink6URL: https://datasheet.ciiva.com/48709/001047902-da-01-en-ic-drv-rx-mulch-max3232ipwr-tssop-16-tid-48709190.pdf?src-supplier=Conrad; Lead Free: Lead Free; Library Name: Schlib1.SchLib; Manufacturer Part Number: MAX3232IPWR; Max Operating Temperature: 85°C; Min Operating Temperature: -40°C; Mount: Surface Mount; Number of Pins: 16; Number of Receivers: 2; Number of Transmitters: 2; Package Quantity: 1; Packaging: Tape and Reel; Pricing 1: 1=0,85, 10=0,546, 50=0,546, 100=0,447, 1000=0,413, 10000=0,37 (USD); Reach SVHC Compliant: No; RoHS: Yes; RoHS Compliant: Yes; Series: -; Stock 1: 72064; Supplier 1: Mouser; Supplier Part Number 1: 595-MAX3232IPWR; Supply Current: 1mA; Weight: 0.002183oz
U3 = PIC18F2523-I/SO: Microchip PIC18F2523-I/SO; 8bit PIC Microcontroller; 40MHz; 32 kB Flash; 28-Pin SOIC; Data Bus Width: 8b; Density: 32KB; Interface: I2C,SPI,UART,USART; Max Power Dissipation: 1W; Max Supply Voltage: 5.5V; Min Supply Voltage: 4.2V; Number of ADC Channels: 10; Number of Bits: 8; Number of I2C Channels: 1; Number of I/Os: 25; Number of PWM Channels: 2; Number of Timers/Counters: 4; Oscillator Type: Internal; Peripherals: Brown-out Detect/Reset,POR,PWM,WDT,HLVD,I2C; RAM Size: 1.5KB; Watchdog Timer: Yes; Case/Package: SOIC; Category: Microcontrollers; Component Kind: Standard; ComponentLink1Description: https://datasheet.ciiva.com/1493/39755c-1493947.pdf?src-supplier=Digikey; ComponentLink1URL: https://datasheet.ciiva.com/1493/39755c-1493947.pdf?src-supplier=Digikey; ComponentLink2Description: https://datasheet.ciiva.com/16478/39755c-16478411.pdf?src-supplier=Future+Electronics; ComponentLink2URL: https://datasheet.ciiva.com/16478/39755c-16478411.pdf?src-supplier=Future+Electronics; ComponentLink3Description: https://datasheet.ciiva.com/7774/39755c-7774347.pdf?src-supplier=Element14; ComponentLink3URL: https://datasheet.ciiva.com/7774/39755c-7774347.pdf?src-supplier=Element14; Core Architecture: PIC; Frequency: 40MHz; Height: 2.35mm; Lead Free: Lead Free; Length: 17.9mm; Library Name: Schlib1.SchLib; Manufacturer: Microchip; Manufacturer Part Number: PIC18F2523-I/SO; Max Frequency: 40MHz; Max Operating Temperature: 85°C; Memory Size: 32KB; Memory Type: FLASH; Min Operating Temperature: -40°C; Mount: Surface Mount; Number of Pins: 28; Number of Programmable I/O: 25; Number of SPI Channels: 1; Number of UART Channels: 1; Package Quantity: 27; Packaging: Rail/Tube; Pricing 1: 54=4,674, 108=4,667 (USD); Radiation Hardening: No; Reach SVHC Compliant: No; RoHS: Yes; RoHS Compliant: Yes; Series: PIC® 18F; Stock 1: 54; Supplier 1: Arrow Electronics; Supplier Part Number 1: PIC18F2523-I/SO; Termination: SMD/SMT; Width: 7.5mm
U4 = MPXH6115A6U: SENSOR ABS PRESS 16.7PSI MAX; Manufacturer: NXP Semiconductors; Accuracy: 1.5%; Max Pressure: 58.02PSI; Max Supply Voltage: 5.25V; Min Supply Voltage: 4.75V; Operating Pressure: 16.68PSI; Operating Supply Voltage: 5V; Output Type: Analog Voltage,Analog; Port Style: No Port; Pressure Type: Absolute; Supply Current: 6mA; Case/Package: SSOP; Category: Pressure Sensors; Component Kind: Standard; ComponentLink1Description: https://datasheet.ciiva.com/2825/mpxa6115a-2825344.pdf?src-supplier=Digikey; ComponentLink1URL: https://datasheet.ciiva.com/2825/mpxa6115a-2825344.pdf?src-supplier=Digikey; ComponentLink2Description: https://datasheet.ciiva.com/1513/1748876-1513862.pdf?src-supplier=Element14; ComponentLink2URL: https://datasheet.ciiva.com/1513/1748876-1513862.pdf?src-supplier=Element14; Library Name: Schlib1.SchLib; Manufacturer Part Number: MPXH6115A6U; Max Operating Temperature: 125°C; Min Operating Temperature: -40°C; Number of Pins: 8; Pricing 1: 65=9,711, 130=9,651, 520=8,857, 1040=8,847 (USD); Reach SVHC Compliant: No; RoHS: No; Series: MPXH6115A; Stock 1: 5; Supplier 1: Arrow Electronics; Supplier Part Number 1: MPXH6115A6U; Termination: PCB
U5 = IRF7101TRPBF: IRF7101PBF Dual N-channel MOSFET Transistor; 3.5 A; 20 V; 8-Pin SOIC; Manufacturer: International Rectifier; Continuous Drain Current (ID): 3.5A; Drain to Source Voltage (Vdss): 20V; Drain-source On Resistance-Max: 100mR; FET Type: 2 N-Channel; Gate to Source Voltage (Vgs): 12V; Input Capacitance: 320pF; Max Power Dissipation: 2W; Turn-Off Delay Time: 24ns; Turn-On Delay Time: 7ns; Case/Package: SOIC; Component Kind: Standard; ComponentLink1Description: https://datasheet.ciiva.com/6385/irf7101pbf-6385610.pdf?src-supplier=Digikey; ComponentLink1URL: https://datasheet.ciiva.com/6385/irf7101pbf-6385610.pdf?src-supplier=Digikey; ComponentLink2Description: https://datasheet.ciiva.com/6385/irf7101pbf-6385613.pdf?src-supplier=Digikey; ComponentLink2URL: https://datasheet.ciiva.com/6385/irf7101pbf-6385613.pdf?src-supplier=Digikey; ComponentLink3Description: https://datasheet.ciiva.com/6385/irf7101pbf-6385615.pdf?src-supplier=Digikey; ComponentLink3URL: https://datasheet.ciiva.com/6385/irf7101pbf-6385615.pdf?src-supplier=Digikey; ComponentLink4Description: https://datasheet.ciiva.com/16359/irf7101pbf-16359627.pdf?src-supplier=Future+Electronics; ComponentLink4URL: https://datasheet.ciiva.com/16359/irf7101pbf-16359627.pdf?src-supplier=Future+Electronics; Current Rating: 3.5A; Height: 1.5mm; Lead Free: Lead Free; Length: 5mm; Library Name: Schlib1.SchLib; Manufacturer Part Number: IRF7101TRPBF; Max Operating Temperature: 150°C; Min Operating Temperature: -55°C; Mount: Surface Mount; Number of Pins: 8; Package Quantity: 1; Packaging: Cut Tape; Rds On Max: 100mR; RoHS: Yes; RoHS Compliant: Yes; Series: HEXFET®; Supplier 1: Allied Electronics; Supplier Part Number 1: 70016974; Voltage Rating (DC): 20V; Width: 4mm
U6 = MC78M05BDTG: MC78M00 Series 0.5 A 5 V Fixed Output SMT LDO Voltage Regulator - DPAK; Manufacturer: ON Semiconductor; Accuracy: 4%; Current Rating: 700mA; Dropout Voltage: 2V; Input Bias Current: 3.2mA; Max Input Voltage: 35V; Max Output Current: 500mA; Min Input Voltage: 7V; Min Output Voltage: 5V; Number of Channels: 1; Output Configuration: Positive; Power Supply Rejection Ratio (PSRR): 80dB; Case/Package: DPAK; Category: Voltage Regulators - Linear; Component Kind: Standard; ComponentLink1Description: https://datasheet.ciiva.com/2052/mc78m00-d-2052360.pdf?src-supplier=Digikey; ComponentLink1URL: https://datasheet.ciiva.com/2052/mc78m00-d-2052360.pdf?src-supplier=Digikey; ComponentLink2Description: https://datasheet.ciiva.com/3224/mc78m00-d-3224079.pdf?src-supplier=Avnet; ComponentLink2URL: https://datasheet.ciiva.com/3224/mc78m00-d-3224079.pdf?src-supplier=Avnet; ComponentLink3Description: https://datasheet.ciiva.com/16381/mc78m00-d-16381163.pdf?src-supplier=Future+Electronics; ComponentLink3URL: https://datasheet.ciiva.com/16381/mc78m00-d-16381163.pdf?src-supplier=Future+Electronics; ComponentLink4Description: https://datasheet.ciiva.com/7992/1746757-7992652.pdf?src-supplier=Element14; ComponentLink4URL: https://datasheet.ciiva.com/7992/1746757-7992652.pdf?src-supplier=Element14; ComponentLink5Description: https://datasheet.ciiva.com/4882/mc78m00-d-88441-4882543.pdf?src-supplier=Mouser; ComponentLink5URL: https://datasheet.ciiva.com/4882/mc78m00-d-88441-4882543.pdf?src-supplier=Mouser; ComponentLink6Description: https://datasheet.ciiva.com/18435/70099623-18435694.pdf?src-supplier=Allied+Electronics; ComponentLink6URL: https://datasheet.ciiva.com/18435/70099623-18435694.pdf?src-supplier=Allied+Electronics; Halogen Free: Halogen Free; Height: 2.38mm; Lead Free: Lead Free; Length: 6.73mm; Library Name: Schlib1.SchLib; Manufacturer Part Number: MC78M05BDTG; Max Operating Temperature: 125°C; Min Operating Temperature: -40°C; Mount: Surface Mount; Nominal Output Voltage: 5V; Nominal Supply Current: 3.2mA; Number of Outputs: 1; Number of Pins: 3; Number of Regulators: 1; Operating Supply Voltage: 35V; Output Current: 500mA; Output Type: Fixed; Output Voltage: 5V; Package Quantity: 75; Packaging: Rail/Tube; Polarity: Positive; Pricing 1: 1=0,22, 200=0,215, 750=0,205, 2000=0,198, 7500=0,185 (USD); Radiation Hardening: No; Reach SVHC Compliant: No; RoHS: Yes; RoHS Compliant: Yes; Series: -; Stock 1: 7370; Supplier 1: Future Electronics; Supplier Part Number 1: 8771974; Width: 6.22mm
X1 = NX5032GA-10.000000MHZ-LN-CD-1: Crystal 10.0000MHZ 8PF SMD; Manufacturer: NDK; ESR (Equivalent Series Resistance): 120R; Frequency: 10MHz; Frequency Stability: 50ppm; Case/Package: SMD/SMT; Category: Crystals; Component Kind: Standard; ComponentLink1Description: https://datasheet.ciiva.com/856/nx5032ga-856809.pdf?src-supplier=Digikey; ComponentLink1URL: https://datasheet.ciiva.com/856/nx5032ga-856809.pdf?src-supplier=Digikey; ComponentLink2Description: https://datasheet.ciiva.com/856/nx5032ga-856810.pdf?src-supplier=Digikey; ComponentLink2URL: https://datasheet.ciiva.com/856/nx5032ga-856810.pdf?src-supplier=Digikey; ComponentLink3Description: https://datasheet.ciiva.com/856/nx5032ga-856811.pdf?src-supplier=Digikey; ComponentLink3URL: https://datasheet.ciiva.com/856/nx5032ga-856811.pdf?src-supplier=Digikey; Frequency Tolerance: 50ppm; Height: 0.059inch; Length: 0.197inch; Library Name: Schlib1.SchLib; Load Capacitance: 8pF; Manufacturer Part Number: NX5032GA-10.000000MHZ-LN-CD-1; Max Operating Temperature: 70°C; Min Operating Temperature: -10°C; Mount: Surface Mount; Number of Pins: 2; Package Quantity: 1; Packaging: Cut Tape; RoHS: Yes; Series: NX5032GA; Supplier 1: Richardson RFPD; Supplier Part Number 1: NX5032GA-10.00E; Width: 0.126inch
Y1 = PKLCS1212E4001-R1: AUDIO PIEZO TRANSDUCER 25V SMD / Audio Buzzer Piezo 75dB Surface Mount Solder Pad T/R; Manufacturer: Murata; Clock Frequency: 4kHz; Nominal Supply Voltage: 3V; Voltage Rating: 1.5V; Washable: No; Category: Speakers; Component Kind: Standard; ComponentLink1Description: https://datasheet.ciiva.com/4625/pklcs-datasheet-202916-4625328.pdf?src-supplier=Mouser; ComponentLink1URL: https://datasheet.ciiva.com/4625/pklcs-datasheet-202916-4625328.pdf?src-supplier=Mouser; ComponentLink2Description: https://datasheet.ciiva.com/16224/s0039e-16224145.pdf?src-supplier=Future+Electronics; ComponentLink2URL: https://datasheet.ciiva.com/16224/s0039e-16224145.pdf?src-supplier=Future+Electronics; ComponentLink3Description: https://datasheet.ciiva.com/11776/0900766b807da0c7-11776763.pdf?src-supplier=Rs; ComponentLink3URL: https://datasheet.ciiva.com/11776/0900766b807da0c7-11776763.pdf?src-supplier=Rs; ComponentLink4Description: https://datasheet.ciiva.com/3333/03787173-3333942.pdf?src-supplier=Distrelec; ComponentLink4URL: https://datasheet.ciiva.com/3333/03787173-3333942.pdf?src-supplier=Distrelec; ComponentLink5Description: https://datasheet.ciiva.com/1323/1626578-1323984.pdf?src-supplier=Element14; ComponentLink5URL: https://datasheet.ciiva.com/1323/1626578-1323984.pdf?src-supplier=Element14; ComponentLink6Description: https://datasheet.ciiva.com/0/s0039e-123.pdf?src-supplier=Digikey; ComponentLink6URL: https://datasheet.ciiva.com/0/s0039e-123.pdf?src-supplier=Digikey; ComponentLink7Description: https://datasheet.ciiva.com/0/s0039e-124.pdf?src-supplier=Digikey; ComponentLink7URL: https://datasheet.ciiva.com/0/s0039e-124.pdf?src-supplier=Digikey; ComponentLink8Description: https://datasheet.ciiva.com/0/s0039e-125.pdf?src-supplier=Digikey; ComponentLink8URL: https://datasheet.ciiva.com/0/s0039e-125.pdf?src-supplier=Digikey; ComponentLink9Description: https://datasheet.ciiva.com/18141/p37e-18141127.pdf?src-supplier=Verical; ComponentLink9URL: https://datasheet.ciiva.com/18141/p37e-18141127.pdf?src-supplier=Verical; ComponentLink10Description: https://datasheet.ciiva.com/8084/p37e-8084265.pdf?src-supplier=Avnet; ComponentLink10URL: https://datasheet.ciiva.com/8084/p37e-8084265.pdf?src-supplier=Avnet; ComponentLink11Description: https://datasheet.ciiva.com/1323/9058-1323985.pdf?src-supplier=Element14; ComponentLink11URL: https://datasheet.ciiva.com/1323/9058-1323985.pdf?src-supplier=Element14; Depth: 12mm; Diameter: 12mm; Frequency: 4kHz; Height: 3mm; Lead Free: Lead Free; Length: 12mm; Library Name: Schlib1.SchLib; Manufacturer Part Number: PKLCS1212E4001-R1; Max Operating Temperature: 70°C; Max Supply Voltage: 25V; Min Operating Temperature: -20°C; Mounting Technology: Surface Mount; Package Quantity: 1; Packaging: Tape and Reel; Pricing: 1=1,04, 10=0,86, 50=0,758, 100=0,718, 1000=0,534, 10000=0,529 (USD); Radiation Hardening: No; Reach SVHC Compliant: No; RoHS: Yes; Self Resonant Frequency: 4kHz; Series: PK; Stock: 27239; Supplier: Mouser; Supplier Part Number: 81-PKLCS1212E4001; Termination: Solder; Voltage Rating (DC): 25V; Width: 12mm
